# Altium schematic sheet FTDI.SchDoc(FTDI)
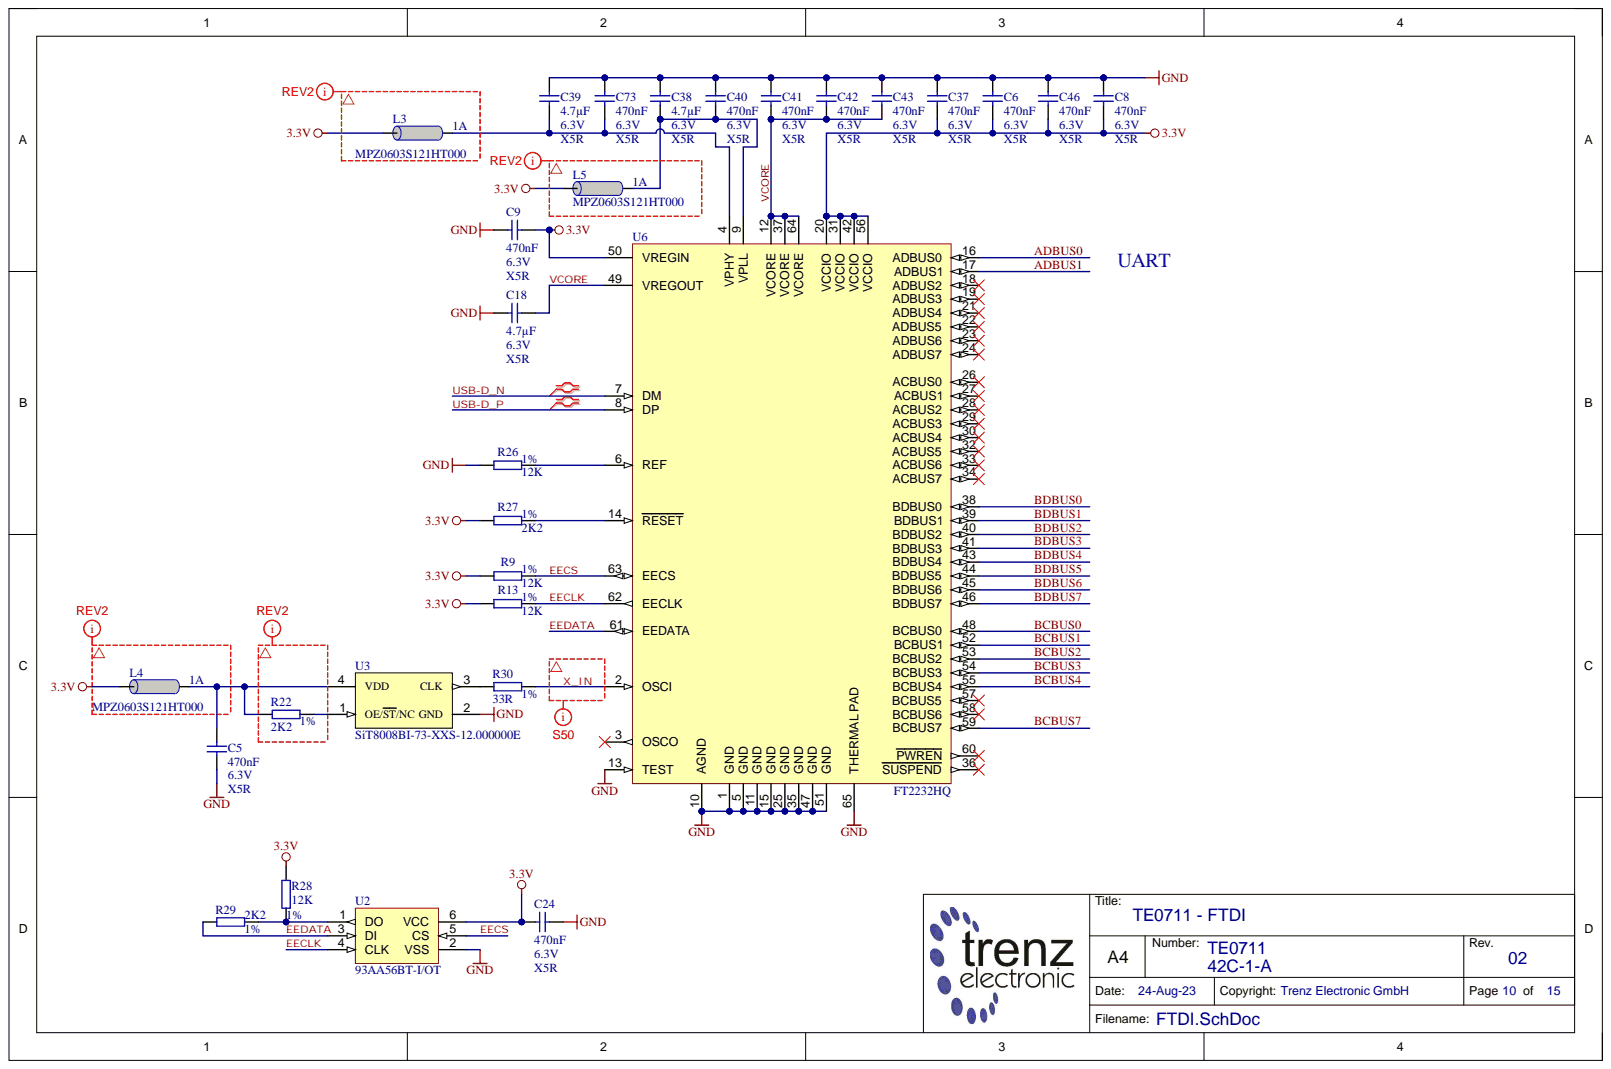
[diagram p0: FTDI.SchDoc]

## Parts
C5  470nF  Technology: X5R  Voltage: 6.3V  [CAPC0603X33N_V]
C6  470nF  Technology: X5R  Voltage: 6.3V  [CAPC0603X33N_V]
C8  470nF  Technology: X5R  Voltage: 6.3V  [CAPC0603X33N_V]
C9  470nF  Voltage: 6.3V  [CAPC0603X33N_V]
C18  4.7µF  Technology: X5R  Voltage: 6.3V  [CAPC1005X55N_V]
C24  470nF  Voltage: 6.3V  [CAPC0603X33N_V]
C37  470nF  Technology: X5R  Voltage: 6.3V  [CAPC0603X33N_V]
C38  4.7µF  Technology: X5R  Voltage: 6.3V  [CAPC1005X55N_V]
C39  4.7µF  Technology: X5R  Voltage: 6.3V  [CAPC1005X55N_V]
C40  470nF  Technology: X5R  Voltage: 6.3V  [CAPC0603X33N_V]
C41  470nF  Technology: X5R  Voltage: 6.3V  [CAPC0603X33N_V]
C42  470nF  Technology: X5R  Voltage: 6.3V  [CAPC0603X33N_V]
C43  470nF  Technology: X5R  Voltage: 6.3V  [CAPC0603X33N_V]
C46  470nF  Technology: X5R  Voltage: 6.3V  [CAPC0603X33N_V]
C73  470nF  Technology: X5R  Voltage: 6.3V  [CAPC0603X33N_V]
L3  MPZ0603S121HT000  Voltage: 1A  [INDC0603X33N_V]
L4  MPZ0603S121HT000  Voltage: 1A  [INDC0603X33N_V]
L5  MPZ0603S121HT000  Voltage: 1A  [INDC0603X33N_V]
R9  12K  Tolerance: 1%  [RESC1005X40N_V]
R13  12K  Tolerance: 1%  [RESC1005X40N_V]
R22  2K2  Tolerance: 1%  [RESC1005X40N_V]
R26  12K  Tolerance: 1%  [RESC1005X40N_V]
R27  2K2  Tolerance: 1%  [RESC1005X40N_V]
R28  12K  Tolerance: 1%  [RESC1005X40N_V]
R29  2K2  Tolerance: 1%  [RESC1005X40N_V]
R30  33R  Tolerance: 1%  [RESC1005X40N_V]
U2  93AA56BT-I/OT  [SOT95P280X145-6N]
U3  SiT8008BI-73-XXS-12.000000E  Database Table Name: CLK  [2016]
U6  FT2232HQ  [QFN50P900X900X100-65N-445]

## Nets
3.3V: C6.2 C8.2 C9.2 C24.1 C37.2 C46.2 L3.2 L4.2 L5.2 R9.1 R13.1 R27.1 R28.1 U2.6(VCC) U6.20(VCCIO) U6.31(VCCIO) U6.42(VCCIO) U6.50(VREGIN) U6.56(VCCIO)
GND: C5.2 C6.1 C8.1 C9.1 C18.1 C24.2 C37.1 C38.1 C39.2 C40.1 C41.1 C42.1 C43.1 C46.1 C73.1 R26.1 U2.2(VSS) U3.2(GND) U6.1(GND) U6.5(GND) U6.10(AGND) U6.11(GND) U6.13(TEST) U6.15(GND) U6.25(GND) U6.35(GND) U6.47(GND) U6.51(GND) U6.65(THERMAL_PAD)
ADBUS0: U6.16(ADBUS0)
ADBUS1: U6.17(ADBUS1)
BCBUS0: U6.48(BCBUS0)
BCBUS1: U6.52(BCBUS1)
BCBUS2: U6.53(BCBUS2)
BCBUS3: U6.54(BCBUS3)
BCBUS4: U6.55(BCBUS4)
BCBUS7: U6.59(BCBUS7)
BDBUS0: U6.38(BDBUS0)
BDBUS1: U6.39(BDBUS1)
BDBUS2: U6.40(BDBUS2)
BDBUS3: U6.41(BDBUS3)
BDBUS4: U6.43(BDBUS4)
BDBUS5: U6.44(BDBUS5)
BDBUS6: U6.45(BDBUS6)
BDBUS7: U6.46(BDBUS7)
EECLK: R13.2 U2.4(CLK) U6.62(EECLK)
EECS: R9.2 U2.5(CS) U6.63(EECS)
EEDATA: R29.1 U2.3(DI) U6.61(EEDATA)
USB-D_N: U6.7(DM)
USB-D_P: U6.8(DP)
VCORE: C18.2 C41.2 C42.2 C43.2 U6.12(VCORE) U6.37(VCORE) U6.49(VREGOUT) U6.64(VCORE)
X_IN: R30.2 U6.2(OSCI)
NetC5_1: C5.1 L4.1 R22.2 U3.4(VDD)
NetC38_2: C38.2 C40.2 L5.1 U6.9(VPLL)
NetC39_1: C39.1 C73.2 L3.1 U6.4(VPHY)
NetR22_1: R22.1 U3.1(OE/ST/NC)
NetR26_2: R26.2 U6.6(REF)
NetR27_2: R27.2 U6.14(RESET)
NetR28_2: R28.2 R29.2 U2.1(DO)
NetR30_1: R30.1 U3.3(CLK)

Not connected: U6 3(OSCO) 18(ADBUS2) 19(ADBUS3) 21(ADBUS4) 22(ADBUS5) 23(ADBUS6) 24(ADBUS7) 26(ACBUS0) 27(ACBUS1) 28(ACBUS2) 29(ACBUS3) 30(ACBUS4) 32(ACBUS5) 33(ACBUS6) 34(ACBUS7) 36(SUSPEND) 57(BCBUS5) 58(BCBUS6) 60(PWREN)

## Library data of the parts
C5 C6 C8 C9 C24 C37 C40-C43 C46 C73 = 24921: KON 470nF 6.3V X5R 20%  SMD BF 0603 (0201); Database Table Name: C_0603_0201; Mixed: SMT; Preferred: Yes; Temperature-Range: -55/+85; Value: 470n; AN: 24921; Case: 0603 (0201); Component Kind: Standard; Library Name: TE.DbLib; Tolerance: 20%
  C9 C24: Technology: X5R
C18 C38 C39 = 24719: KON 4.7µF 6.3V X5R 20% SMD BF 1005 (0402); Database Table Name: C_1005_0402; Mixed: SMT; Preferred: Yes; Temperature-Range: -55/+85; Value: 4.7u; AN: 24719; Case: 1005 (0402); Component Kind: Standard; Library Name: TE.DbLib; Tolerance: 20%
L3-L5 = 30910: Ferritbead MPZ0603S121HT000; Database Table Name: L_0603_0201; Extra: 0,13 Ohm DC, 120 Ohm@100MHz. 1A , 25%; Mixed: SMT; Preferred: Yes; Temperature-Range: -55/+125 (Abs); Tolerance: 25%; Value: 120 Ohm; AN: 30910; Case: 0603 (0201); Component Kind: Standard; H-ART: MPZ0603S121HT000; Library Name: TE.DbLib; Storage-Temperature: -55/+125 (Abs); Technology: 0.13 Ohm; Trenz-Stock: Yes; HelpURL: b:\AD\adlib\datasheet\Murata\MPZ0603-H\
R9 R13 R26 R28 = 22598: WID SMD 12K 1% 0,063W  BF 1005 (0402); Database Table Name: R_1005_0402; Mixed: SMT; Preferred: Yes; Voltage: 0.063W; AN: 22598; Case: 1005 (0402); Component Kind: Standard; Library Name: TE.DbLib; Technology: TK100; Value: 12K
R22 R27 R29 = 22748: WID SMD 2K2 1% 0,063W BF 1005 (0402); Database Table Name: R_1005_0402; H-ART: RC0402FR-132K2L; Mixed: SMT; Preferred: Yes; Value: 2.2K; Voltage: 0.063W; AN: 22748; Case: 1005 (0402); Component Kind: Standard; Library Name: TE.DbLib; Technology: TK100
R30 = 22770: WID SMD 33R 1% 0,063W BF 1005 (0402); Database Table Name: R_1005_0402; H-ART: RC0402FR-0733RL; Mixed: SMT; Preferred: Yes; Value: 33; Voltage: 0.063W; AN: 22770; Case: 1005 (0402); Component Kind: Standard; Library Name: TE.DbLib; Technology: TK100
U2 = 24730: Database Table Name: EEPROM; Extra: 2K Microwire Compatible Serial EEPROM 128x16 - 1.8V; Mixed: SMT; Preferred: Yes; Temperature-Range: -40/+85; AN: 24730; Case: SOT23-6; Component Kind: Standard; Description: 93AA56BT-I/OT; H-ART: 93AA56BT-I/OT; Library Name: TE.DbLib; Trenz-Stock: Yes; HelpURL: B:\AD\adlib\datasheet\93AA56A
U3 = 30735: SiT8008BI-73-XXS-12.000000E MEMS Oszillator 12MHz; Extra: 2,25 - 3,63V , -40° C - 85° C, +/-50 ppm, 2 x1,6 mm; Mixed: SMT; Preferred: Yes; Temperature-Range: -40/+85; Value: 12M; Voltage: 2.5-3.3V; AN: 30735; Case: 2.0 x 1.6mm; Component Kind: Standard; H-ART: SiT8008BI-73-XXS-12.000000E; Library Name: TE.DbLib; Storage-Temperature: -65/+150 (Abs); Trenz-Stock: Yes; HelpURL: B:\AD\adlib\datasheet\SiT8008
U6 = 24727: Database Table Name: IF; Extra: Dual High Speed USB to Multipurpose UART/FIFO IC; Mixed: SMT; Preferred: Yes; Temperature-Range: -40/+85; AN: 24727; Case: QFN64; Component Kind: Standard; Description: FT2232HQ; H-ART: FT2232HQ; Library Name: TE.DbLib; Trenz-Stock: Yes; HelpURL: B:\AD\adlib\datasheet\FT2232H
